# Altium schematic sheet CC_pwr-moteur.SchDoc
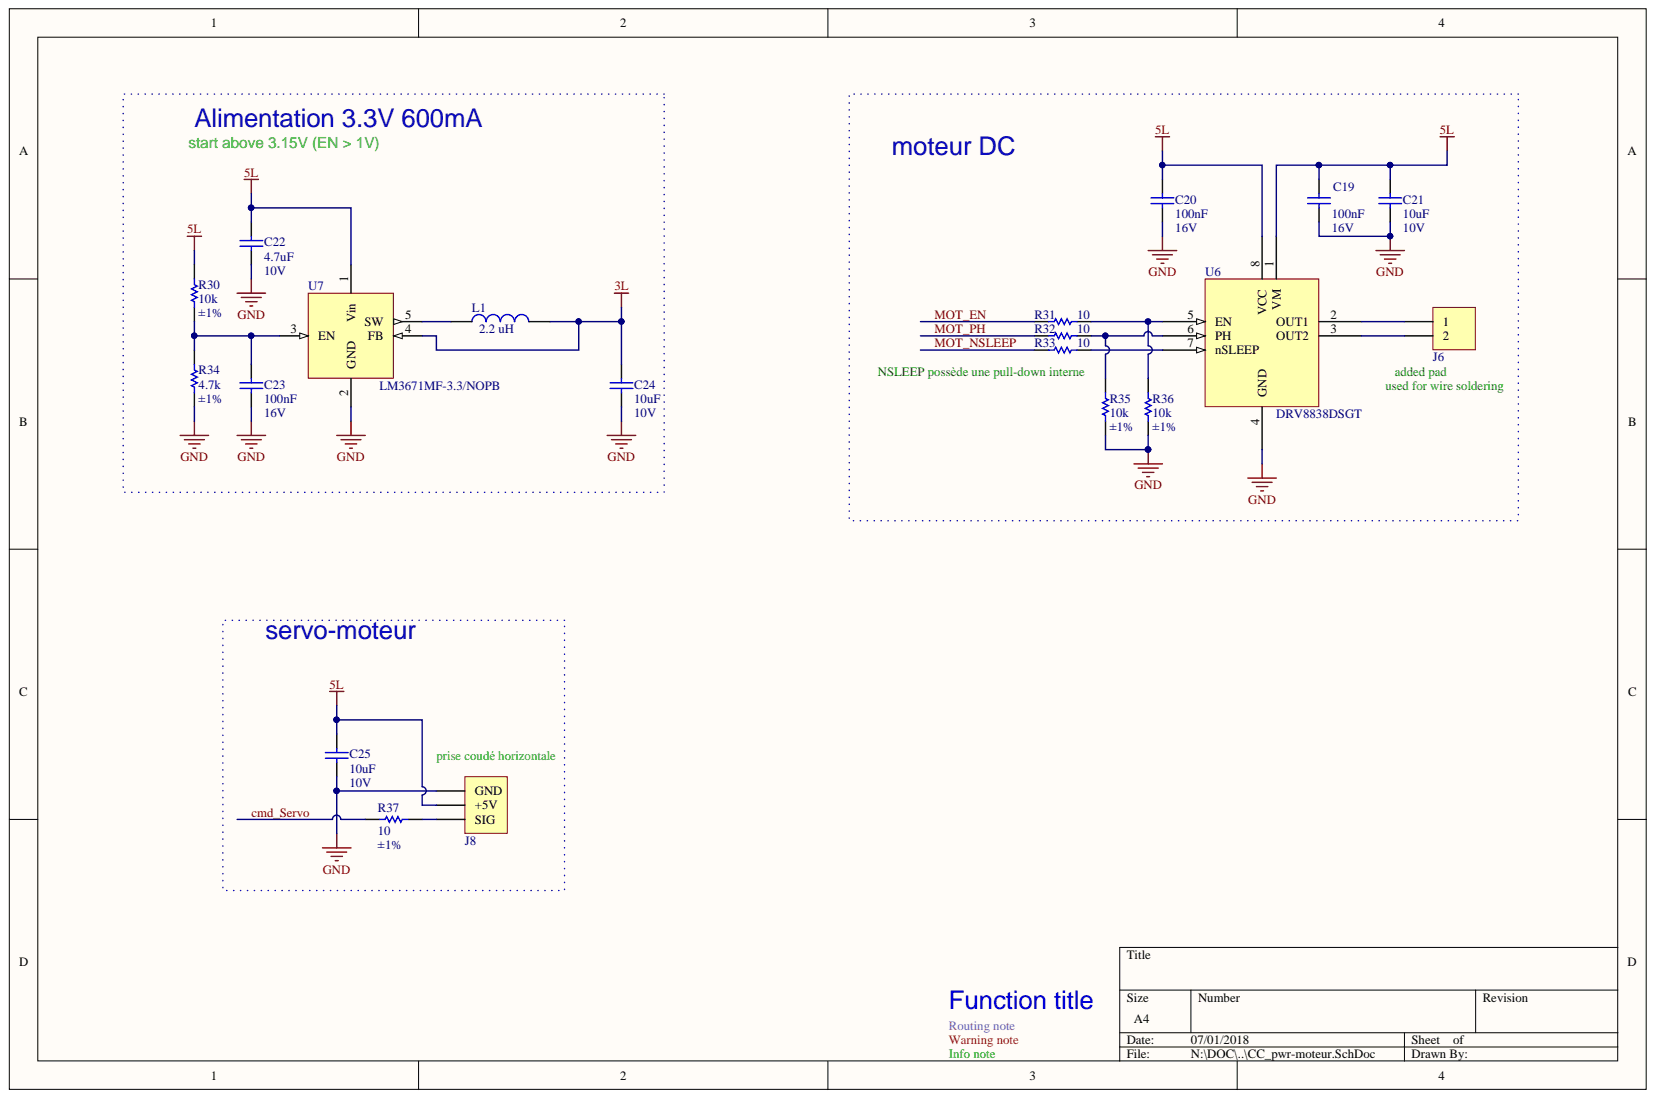
[diagram p0: CC_pwr-moteur.SchDoc]

## Parts
C19  100nF  RatedVoltage: 16V  [WCAP-CSGP_0402, 1x0.5x0.5]
C20  100nF  RatedVoltage: 16V  [WCAP-CSGP_0402, 1x0.5x0.5]
C21  10uF  RatedVoltage: 10V  [WCAP-CSGP_0805, 2x1.25x1.25]
C22  4.7uF  RatedVoltage: 10V  [WCAP-CSGP_0603, 1.6x0.8x0.8]
C23  100nF  RatedVoltage: 16V  [WCAP-CSGP_0402, 1x0.5x0.5]
C24  10uF  RatedVoltage: 10V  [WCAP-CSGP_0805, 2x1.25x1.25]
C25  10uF  RatedVoltage: 10V  [WCAP-CSGP_0805, 2x1.25x1.25]
J6  value 2  [653102131822]  (hidden comment: 653102131822)
J8  61300311021  [61300311021]  (value not drawn)
L1  value 2.2 uH  [WE-LQS_2010]  (hidden comment: 74404020022)
R30  value 10k  Precision: ±1%  [RESC1005X04N]  (hidden comment: RC0402FR-0710KL)
R31  value 10  [RESC1005X04N]  (hidden comment: RC0402FR-0710RL)
R32  value 10  [RESC1005X04N]  (hidden comment: RC0402FR-0710RL)
R33  value 10  [RESC1005X04N]  (hidden comment: RC0402FR-0710RL)
R34  value 4.7k  Precision: ±1%  [RESC1005X04N]  (hidden comment: Manufacturer Part Number)
R35  value 10k  Precision: ±1%  [RESC1005X04N]  (hidden comment: RC0402FR-0710KL)
R36  value 10k  Precision: ±1%  [RESC1005X04N]  (hidden comment: RC0402FR-0710KL)
R37  value 10  Precision: ±1%  [RESC1005X04N]  (hidden comment: RC0402FR-0710RL)
U6  DRV8838DSGT  [PSON50P200X80_HS-9N]
U7  LM3671MF-3.3/NOPB  [SOT23-5]

## Nets
3L: C24.2 L1.2 U7.4(FB)
5L: C19.2 C20.2 C21.2 C22.2 C25.2 J8.2 R30.2 U6.1(VM) U6.8(VCC) U7.1(Vin)
GND: C19.1 C20.1 C21.1 C22.1 C23.1 C24.1 C25.1 J8.1 R34.1 R35.1 R36.1 U6.4(GND) U7.2(GND)
CMD_SERVO: R37.1
MOT_EN: R31.1
MOT_NSLEEP: R33.1
MOT_PH: R32.1
NetC23_2: C23.2 R30.1 R34.2 U7.3(EN)
NetJ6_1: J6.1 U6.2(OUT1)
NetJ6_2: J6.2 U6.3(OUT2)
NetJ8_3: J8.3 R37.2
NetL1_1: L1.1 U7.5(SW)
NetR31_2: R31.2 R36.2 U6.5(EN)
NetR32_2: R32.2 R35.2 U6.6(PH)
NetR33_2: R33.2 U6.7(nSLEEP)

## Library data of the parts
C19 C20 C23 = WCAP-CSGP, 885012205037: CAP CER 100nF 16V X7R 0402; Manufacturer: Wurth Elektronik; CeramicType: X7R; Component Kind: Standard; ComponentLink1Description: Manufacturer; ComponentLink1URL: http://www.we-online.de; ComponentLink2Description: Datasheet; ComponentLink2URL: http://katalog.we-online.com/pbs/datasheet/885012205037.pdf; Manufacturer Part Number: 885012205037; PublishedDate: Aug 2016; Size: 0402; Value: 100nF; Library Name: Condensateur.IntLib
C21 C24 C25 = WCAP-CSGP, 885012207026: CAP CER 10uF 10V X7R 0805; Manufacturer: Wurth Elektronik; CeramicType: X7R; Component Kind: Standard; ComponentLink1Description: Manufacturer; ComponentLink1URL: http://www.we-online.de; ComponentLink2Description: Datasheet; ComponentLink2URL: http://katalog.we-online.com/pbs/datasheet/885012207026.pdf; Manufacturer Part Number: 885012207026; PublishedDate: Aug 2016; Size: 0805; Value: 10uF; Library Name: Condensateur.IntLib
C22 = WCAP-CSGP, 885012106012: CAP CER 4.7UF 10V X5R 0603; Manufacturer: Wurth Elektronik; CeramicType: X5R; Component Kind: Standard; ComponentLink1Description: Manufacturer; ComponentLink1URL: http://www.we-online.de; ComponentLink2Description: Datasheet; ComponentLink2URL: http://katalog.we-online.com/pbs/datasheet/885012106012.pdf; Manufacturer Part Number: 885012106012; PublishedDate: Aug 2016; Size: 0603; Value: 4.7uF; Library Name: Condensateur.IntLib
J6 = 653102131822: WR-WTB 1.25mm; Manufacturer 1: Wuerth Elektronik; ComponentLink1 Description: Manufacturer; ComponentLink2 Description: Datasheet; Component Kind: Standard; ComponentLink1URL: http://www.we-online.com; ComponentLink2URL: http://katalog.we-online.de/em/datasheet/6531xx131822.pdf; Manufacturer Part Number: 653102131822; Supplier 1: farnell; Supplier Part Number 1: 1841413; Library Name: Connecteurs.IntLib
J8 = 61300311021: CONN HEADER 3 POS RA 2.54mm; Manufacturer: Wurth Electronics Inc.; Component Kind: Standard; ComponentLink1Description: Datasheet; ComponentLink1URL: http://katalog.we-online.de/em/datasheet/6130xx11021.pdf; Library Name: Wurth.SchLib; Manufacturer Part Number: 61300311021; Supplier 1: Farnell; Supplier Part Number 1: 2356177
L1 = 74404020022: FIXED IND 2.2UH 960MA 220 MOHM; Manufacturer: Wurth Elektronik; RatedCurrent: 0.96 A; Component Kind: Standard; ComponentLink1Description: Manufacturer; ComponentLink1URL: http://www.we-online.de; ComponentLink2Description: Datasheet; ComponentLink2URL: http://katalog.we-online.de/pbs/datasheet/74404020022.pdf; Library Name: Inductance (1).IntLib; Manufacturer Part Number: 74404020022; PublishedDate: Nov. 2016; Size: 2010
R30 R35 R36 = RC0402FR-0710KL: RES SMD 10K OHM 1% 1/16W 0402; Manufacturer: Yageo; Power: 63mW; Vmax: 50V; Component Kind: Standard; ComponentLink1Description: Manufacturer; ComponentLink1URL: http://www.yageo.com/NewPortal/_en/index.jsp; ComponentLink2Description: Datasheet; ComponentLink2URL: http://www.farnell.com/datasheets/2167052.pdf; Manufacturer Part Number: RC0402FR-0710KL; Supplier 1: Farnell; Supplier Part Number 1: 9239359; Type: Thick Film; Library Name: Resistance.IntLib
R31-R33 R37 = RC0402FR-0710RL: RES SMD 10 OHM 1% 1/16W 0402; Manufacturer: Yageo; Power: 63mW; Vmax: 50V; Component Kind: Standard; ComponentLink1Description: Manufacturer; ComponentLink1URL: http://www.yageo.com/NewPortal/_en/index.jsp; ComponentLink2Description: Datasheet; ComponentLink2URL: http://www.farnell.com/datasheets/1018594.pdf; Manufacturer Part Number: RC0402FR-0710RL; Supplier 1: Farnell; Supplier Part Number 1: 9238999; Type: Thick Film; Library Name: Resistance.IntLib
  R31-R33: Precision: ±1%
R34 = RC0402FR-074K7L: RES SMD 4K7 OHM 1% 1/16W 0402; Manufacturer: Yageo; Power: 63mW; Vmax: 50V; Component Kind: Standard; ComponentLink1Description: Manufacturer; ComponentLink1URL: http://www.yageo.com/NewPortal/_en/index.jsp; ComponentLink2Description: Datasheet; ComponentLink2URL: http://www.farnell.com/datasheets/2167052.pdf; Manufacturer Part Number: RC0402FR-074K7L; Supplier 1: Farnell; Supplier Part Number 1: 9239316; Type: Thick Film; Library Name: Resistance.IntLib
U6 = DRV8838DSGT: IC BRIDGE DRIVER PAR 8WSON; Manufacturer: Texas Instruments; Component Kind: Standard; ComponentLink1Description: Datasheet; ComponentLink1URL: http://www.ti.com/lit/ds/symlink/drv8838.pdf; Manufacturer Part Number: DRV8838DSGT; Supplier 1: Farnell; Supplier Part Number 1: 2420232; Library Name: CI.SchLib
U7 = LM3671MF-3.3/NOPB: IC REG BUCK 3.3V 0.6A SYNC SOT23; Manufacturer: Texas Instruments; Component Kind: Standard; ComponentLink1Description: Datasheet; ComponentLink1URL: http://www.ti.com/lit/ds/symlink/lm3671.pdf; Library Name: DC_DC_Converter.SchLib; Manufacturer Part Number: LM3671MF-3.3/NOPB; Supplier 1: Farnell; Supplier Part Number 1: 1685767
